# Altium schematic sheet 04_POWER_LDO.SchDoc(04_POWER_LDO)
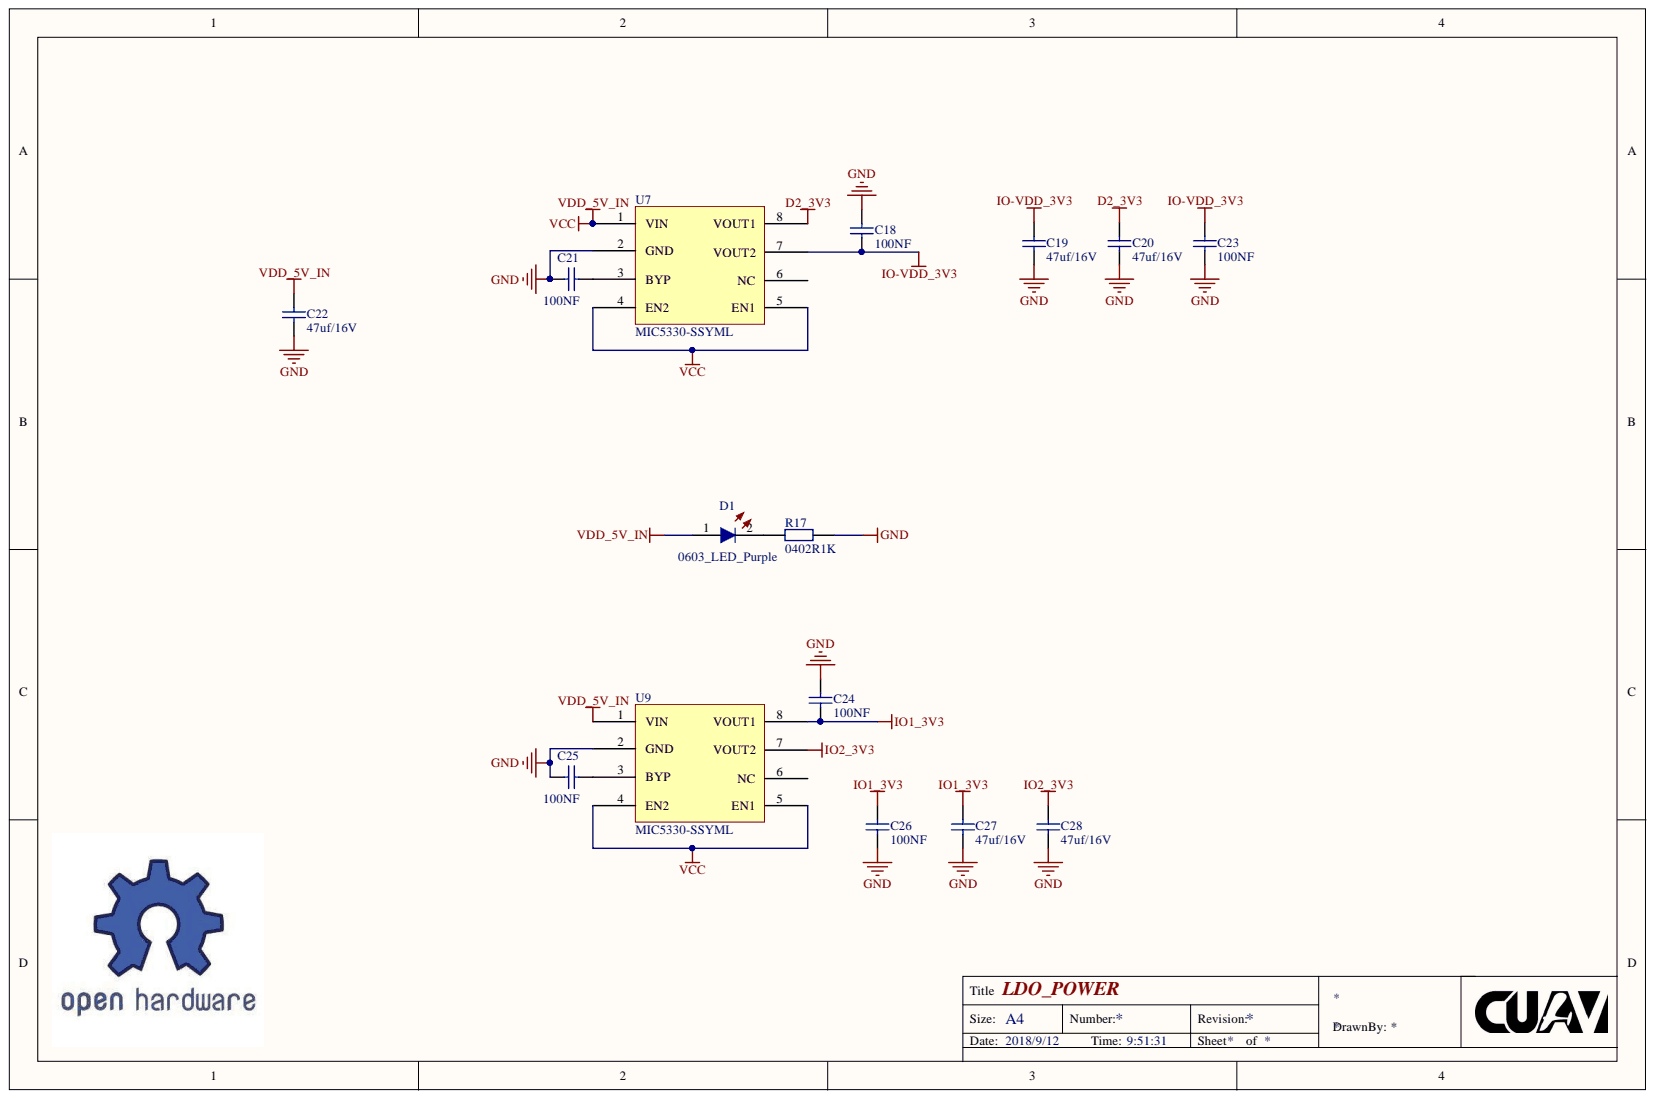
[diagram p0: 04_POWER_LDO.SchDoc]

## Parts
C18  100NF  [0402CAP]
C19  47uf/16V  [0805CAP]
C20  47uf/16V  [0805CAP]
C21  100NF  [0402CAP]
C22  47uf/16V  [0805CAP]
C23  100NF  [0402CAP]
C24  100NF  [0402CAP]
C25  100NF  [0402CAP]
C26  100NF  [0402CAP]
C27  47uf/16V  [0805CAP]
C28  47uf/16V  [0805CAP]
D1  0603_LED_Purple  [0603-LED-RED]
R17  0402R1K  [0402R]
U7  MIC5330-SSYML  [MIC5530]
U9  MIC5330-SSYML  [MIC5530]

## Nets
D2_3V3: C20.1 U7.8(VOUT1)
GND: C18.2 C19.2 C20.2 C21.2 C22.2 C23.1 C24.2 C25.2 C26.1 C27.2 C28.2 R17.2 U7.2(GND) U9.2(GND)
IO1_3V3: C24.1 C26.2 C27.1 U9.8(VOUT1)
IO2_3V3: C28.1 U9.7(VOUT2)
IO-VDD_3V3: C18.1 C19.1 C23.2 U7.7(VOUT2)
VCC: C22.1 D1.1 U7.1(VIN) U7.4(EN2) U7.5(EN1) U9.1(VIN) U9.4(EN2) U9.5(EN1)
NetC21_1: C21.1 U7.3(BYP)
NetC25_1: C25.1 U9.3(BYP)
NetD1_2: D1.2 R17.1

Not connected: U7 6(NC); U9 6(NC)

## Library data of the parts
C18 C21 C24-C26 = Cap: 0402 100NF 50V 10%; Simulation: CAP; Signal Integrity: Cap; Library Name: Miscellaneous Devices.IntLib; Component Kind: Standard
C19 C20 C22 C27 C28 = Cap: 0805 47UF 16V; Simulation: CAP; Signal Integrity: Cap; Library Name: Miscellaneous Devices.IntLib; Component Kind: Standard
C23 = Cap: Simulation: CAP; Signal Integrity: Cap; Library Name: Miscellaneous Devices.IntLib; Component Kind: Standard
D1 = 0603_LED_RED: Description: 0603_LED_RED; Component Kind: Standard; Library Name: CUAV.SchLib
R17 = 0402R1K: 0402R200 1%; Component Kind: Standard; Library Name: CUAV.SchLib
U7 U9 = MIC5530: Component Kind: Standard; Library Name: hack.SchLib
